# Altium schematic sheet MCU.SchDoc(U_MCU)
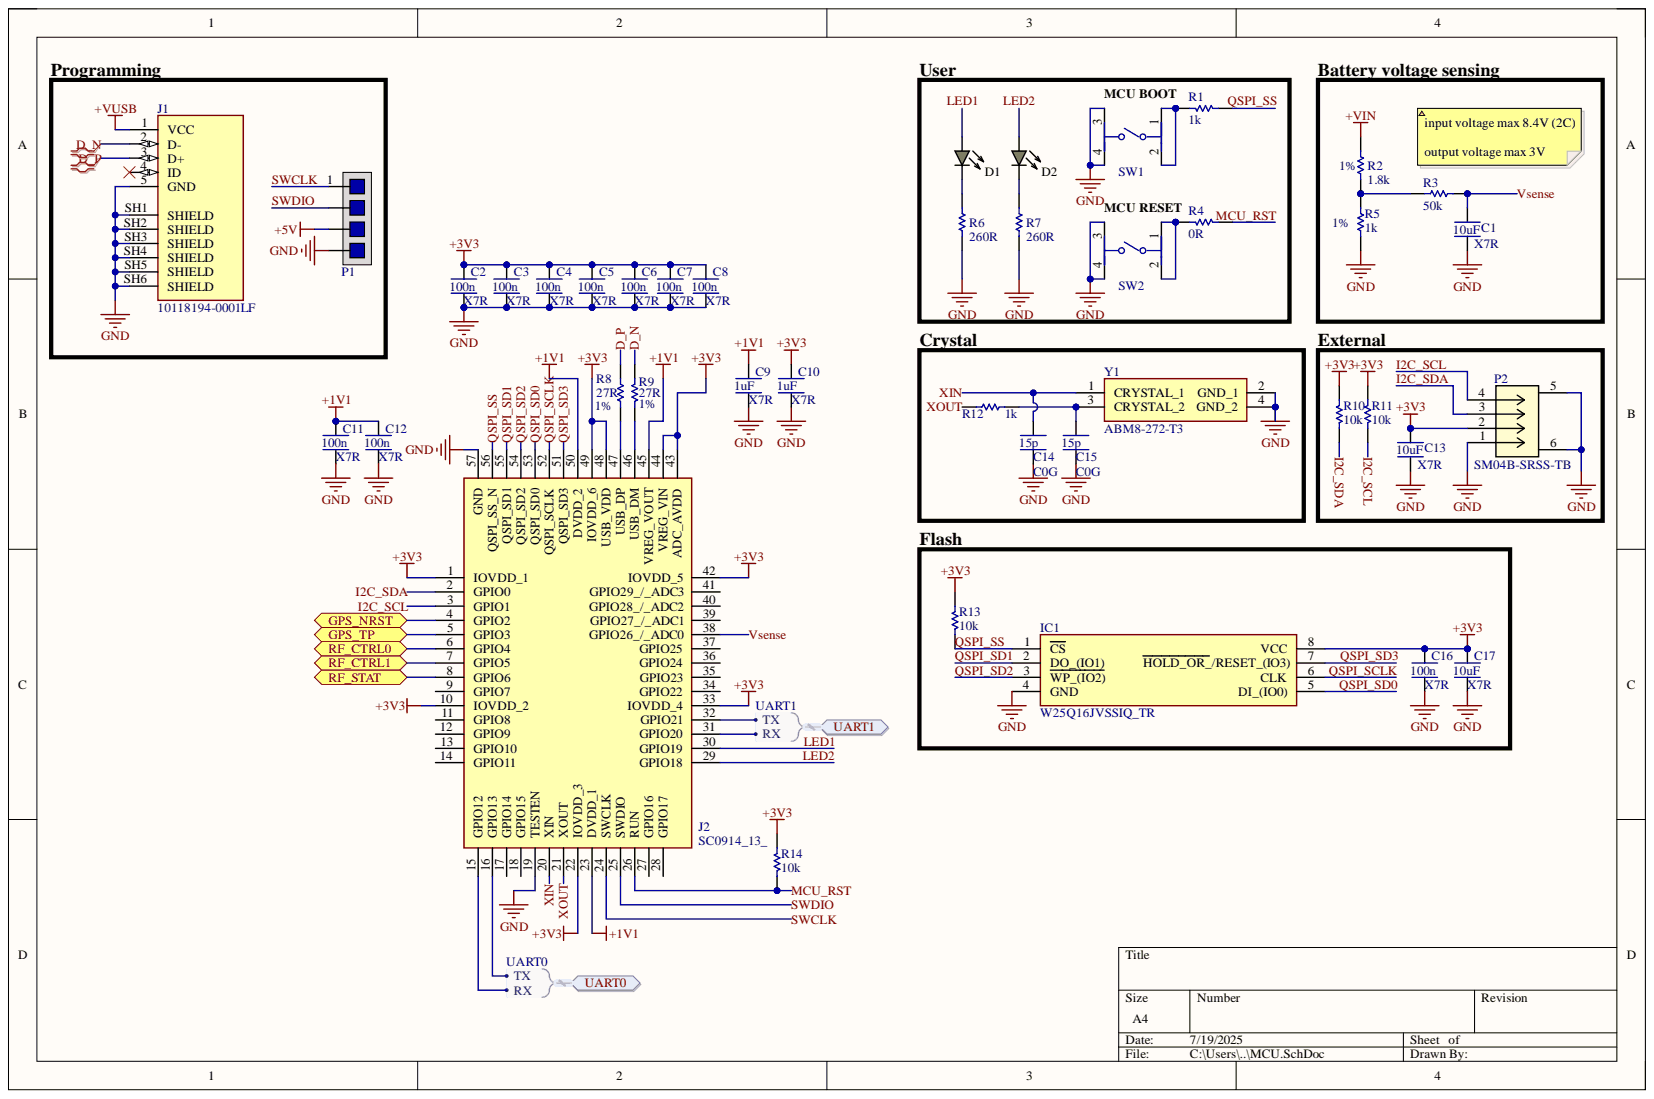
[diagram p0: MCU.SchDoc]

## Parts
C1  10uF  Dielectric: X7R  [CAP_1206]
C2  100n  Dielectric: X7R  [CAP_0603]
C3  100n  Dielectric: X7R  [CAP_0603]
C4  100n  Dielectric: X7R  [CAP_0603]
C5  100n  Dielectric: X7R  [CAP_0603]
C6  100n  Dielectric: X7R  [CAP_0603]
C7  100n  Dielectric: X7R  [CAP_0603]
C8  100n  Dielectric: X7R  [CAP_0603]
C9  1uF  Dielectric: X7R  [CAP_0603]
C10  1uF  Dielectric: X7R  [CAP_0603]
C11  100n  Dielectric: X7R  [CAP_0603]
C12  100n  Dielectric: X7R  [CAP_0603]
C13  10uF  Dielectric: X7R  [CAP_1206]
C14  15p  Dielectric: C0G  [CAP_0603]
C15  15p  Dielectric: C0G  [CAP_0603]
C16  100n  Dielectric: X7R  [CAP_0603]
C17  10uF  Dielectric: X7R  [CAP_0603]
D1  green  [LED_0603]  (value not drawn)
D2  green  [LED_0603]  (value not drawn)
IC1  W25Q16JVSSIQ_TR  [SOIC127P790X216-8N]
J1  10118194-0001LF  [10118194-0001LF]
J2  SC0914_13_  [SC091413]
P1  4_POS 2.54mm  [4 POS VIAS]  (value not drawn)
P2  SM04B-SRSS-TB  [SM04B-SRSS-TB]
R1  1k  [RES_0603]
R2  1.8k  tolerance: 1%  [RES_0603]
R3  50k  [RES_0603]
R4  0R  [RES_0603]
R5  1k  tolerance: 1%  [RES_0603]
R6  260R  [RES_0603]
R7  260R  [RES_0603]
R8  27R  tolerance: 1%  [RES_0603]
R9  27R  tolerance: 1%  [RES_0603]
R10  10k  [RES_0603]
R11  10k  [RES_0603]
R12  1k  [RES_0603]
R13  10k  [RES_0603]
R14  10k  [RES_0603]
SW1  PTS526SK15SMTR2LFS  [FP-PTS526SK15SMTR2LFS-MFG]  (value not drawn)
SW2  PTS526SK15SMTR2LFS  [FP-PTS526SK15SMTR2LFS-MFG]  (value not drawn)
Y1  ABM8-272-T3  [ABM8272T3]

## Ports
GPS_NRST, GPS_TP, RF_CTRL0, RF_CTRL1, RF_STAT, UART0, UART0.RX, UART0.TX, UART1, UART1.RX, UART1.TX

## Nets
+1V1: C9.2 C11.2 C12.2 J2.23(DVDD_1) J2.45(VREG_VOUT) J2.50(DVDD_2)
+3V3: C2.2 C3.2 C4.2 C5.2 C6.2 C7.2 C8.2 C10.2 C13.2 C16.2 C17.2 IC1.8(VCC) J2.1(IOVDD_1) J2.10(IOVDD_2) J2.22(IOVDD_3) J2.33(IOVDD_4) J2.42(IOVDD_5) J2.43(ADC_AVDD) J2.44(VREG_VIN) J2.48(USB_VDD) J2.49(IOVDD_6) P2.2 R10.1 R11.1 R13.1 R14.1
+5V: P1.3
+VIN: R2.2
+VUSB: J1.1(VCC)
GND: C1.1 C2.1 C3.1 C4.1 C5.1 C6.1 C7.1 C8.1 C9.1 C10.1 C11.1 C12.1 C13.1 C14.1 C15.1 C16.1 C17.1 IC1.4(GND) J1.5(GND) J1.SH1(SHIELD) J1.SH2(SHIELD) J1.SH3(SHIELD) J1.SH4(SHIELD) J1.SH5(SHIELD) J1.SH6(SHIELD) J2.19(TESTEN) J2.57(GND) P1.4 P2.1 P2.5 P2.6 R5.2 R6.1 R7.1 SW1.3 SW1.4 SW2.3 SW2.4 Y1.2(GND_1) Y1.4(GND_2)
D_N: J1.2(D-) R9.2
D_P: J1.3(D+) R8.2
GPS_NRST: J2.4(GPIO2) ; port GPS_NRST
GPS_TP: J2.5(GPIO3) ; port GPS_TP
I2C_SCL: J2.3(GPIO1) P2.4 R11.2
I2C_SDA: J2.2(GPIO0) P2.3 R10.2
LED1: D1.1 J2.30(GPIO19)
LED2: D2.1 J2.29(GPIO18)
MCU_RST: J2.26(RUN) R4.1 R14.2
QSPI_SCLK: IC1.6(CLK) J2.52(QSPI_SCLK)
QSPI_SD0: IC1.5(DI_(IO0)) J2.53(QSPI_SD0)
QSPI_SD1: IC1.2(DO_(IO1)) J2.55(QSPI_SD1)
QSPI_SD2: IC1.3(WP_(IO2)) J2.54(QSPI_SD2)
QSPI_SD3: IC1.7(HOLD_OR_/RESET_(IO3)) J2.51(QSPI_SD3)
QSPI_SS: IC1.1(CS) J2.56(QSPI_SS_N) R1.1 R13.2
RF_CTRL0: J2.6(GPIO4) ; port RF_CTRL0
RF_CTRL1: J2.7(GPIO5) ; port RF_CTRL1
RF_STAT: J2.8(GPIO6) ; port RF_STAT
SWCLK: J2.24(SWCLK) P1.1
SWDIO: J2.25(SWDIO) P1.2
Vsense: C1.2 J2.38(GPIO26_/_ADC0) R3.2
XIN: C14.2 J2.20(XIN) Y1.1(CRYSTAL_1)
XOUT: J2.21(XOUT) R12.1
NetC15_2: C15.2 R12.2 Y1.3(CRYSTAL_2)
NetD1_2: D1.2 R6.2
NetD2_2: D2.2 R7.2
NetJ1_4: J1.4(ID)
NetJ2_9: J2.9(GPIO7)
NetJ2_11: J2.11(GPIO8)
NetJ2_12: J2.12(GPIO9)
NetJ2_13: J2.13(GPIO10)
NetJ2_14: J2.14(GPIO11)
NetJ2_15: J2.15(GPIO12) ; port UART0
NetJ2_16: J2.16(GPIO13) ; port UART0
NetJ2_17: J2.17(GPIO14)
NetJ2_18: J2.18(GPIO15)
NetJ2_27: J2.27(GPIO16)
NetJ2_28: J2.28(GPIO17)
NetJ2_31: J2.31(GPIO20) ; port UART1
NetJ2_32: J2.32(GPIO21) ; port UART1
NetJ2_34: J2.34(GPIO22)
NetJ2_35: J2.35(GPIO23)
NetJ2_36: J2.36(GPIO24)
NetJ2_37: J2.37(GPIO25)
NetJ2_39: J2.39(GPIO27_/_ADC1)
NetJ2_40: J2.40(GPIO28_/_ADC2)
NetJ2_41: J2.41(GPIO29_/_ADC3)
NetJ2_46: J2.46(USB_DM) R9.1
NetJ2_47: J2.47(USB_DP) R8.1
NetR1_2: R1.2 SW1.1 SW1.2
NetR2_1: R2.1 R3.1 R5.1
NetR4_2: R4.2 SW2.1 SW2.2

## Library data of the parts
C1 C13 = CAP_1206: Capacitor SMD 1206 generic; Component Kind: Standard; Library Name: myLib.SchLib; Value: 10uF
C2-C12 C14-C17 = CAP_0603: Capacitor SMD 0603 generic; Component Kind: Standard; Library Name: myLib.SchLib
  C2-C8 C11 C12 C16: Value: 100n
  C9 C10: Value: 1uF
  C14 C15: Value: 15p
  C17: Value: 10uF
D1 D2 = LED_0603: LED SMD 0603 generic; Current (mA): 5mA; Forward voltage: 2.1; Color: green; Component Kind: Standard; ComponentLink1Description: New link; ComponentLink1URL: https://www.digikey.com.au/en/products/detail/liteon/LTST-C190GKT/269230; Library Name: myLib.SchLib
IC1 = W25Q16JVSSIQ_TR: Integrated Circuit; Manufacturer_Name: Winbond; Manufacturer_Part_Number: W25Q16JVSSIQ TR; Arrow Part Number: W25Q16JVSSIQ TR; Arrow Price/Stock: https://www.arrow.com/en/products/w25q16jvssiqtr/winbond-electronics?utm_currency=USD&region=nac; Component Kind: Standard; Datasheet Link: https://www.winbond.com/resource-files/w25q16jv%20spi%20revg%2003222018%20plus.pdf; Height: 2.16mm; Mouser Part Number: 454-W25Q16JVSSIQTR; Mouser Price/Stock: https://www.mouser.co.uk/ProductDetail/Winbond/W25Q16JVSSIQ-TR?qs=qSfuJ%252Bfl%2Fd6oXlsNm7Svfg%3D%3D
J1 = 10118194-0001LF: MICRO-USB; Component Kind: Standard; Library Name: myLib.SchLib
J2 = SC0914_13_: Connector; Manufacturer_Name: RASPBERRY-PI; Manufacturer_Part_Number: SC0914(13); Component Kind: Standard; Datasheet Link: https://datasheets.raspberrypi.com/rp2040/rp2040-datasheet.pdf; Height: 0.9mm; Mouser Part Number: 358-SC091413; Mouser Price/Stock: https://www.mouser.co.uk/ProductDetail/Raspberry-Pi/SC091413?qs=T%252BzbugeAwjhr%252BUbBr3TJcw%3D%3D
P2 = SM04B-SRSS-TB: Connector Header Surface Mount, Right Angle 4 position 0.039" (1.00mm); Component Kind: Standard; Library Name: SamacSys.SchLib
R1 R2 R4-R14 = RES_0603: resistor SMD 0603 generic; Component Kind: Standard; Library Name: myLib.SchLib
  R1 R5 R12: value: 1k
  R2: value: 1.8k
  R4: value: 0R
  R6 R7: value: 260R
  R8 R9: value: 27R
  R10 R11 R13 R14: value: 10k
R3 = RES_0603: resistor SMD 0603 generic; value: 15k; Component Kind: Standard; Library Name: myLib.SchLib
SW1 SW2 = CMP-011-00053-5: Switch Tactile N.O. SPST Round Button Gull Wing 0.05A 12VDC 200000Cycles 2.45N SMD T/R; Component Kind: Standard; Component Type: Standard; Design Item ID: CMP-011-00021-1; Max Operating Temperature: 70°C; Min Operating Temperature: -20°C; Mounting Technology: Surface Mount; Pins: 4; Throw Configuration: SPST; Library Name: UTS Rocketry Workspace; Source: UTSRocketryIntLib.SchLib
Y1 = ABM8-272-T3: Crystal or Oscillator; Manufacturer_Name: ABRACON; Component Kind: Standard; Datasheet Link: https://abracon.com/datasheets/ABM8-272-T3.pdf; Height: 0.8mm; Library Name: SamacSys.SchLib; Manufacturer_Part_Number: ABM8-272-T3; Mouser Part Number: 815-ABM8-272-T3; Mouser Price/Stock: https://www.mouser.co.uk/ProductDetail/ABRACON/ABM8-272-T3?qs=QpmGXVUTftEj6miOiJBMxQ%3D%3D
P1 = 4_POS 2.54mm: Component Kind: Standard; Library Name: myLib.SchLib
